# Altium schematic sheet СФ МЭИ ЛБ ПЭ2-18 11.03.04 03 Э3.SchDoc
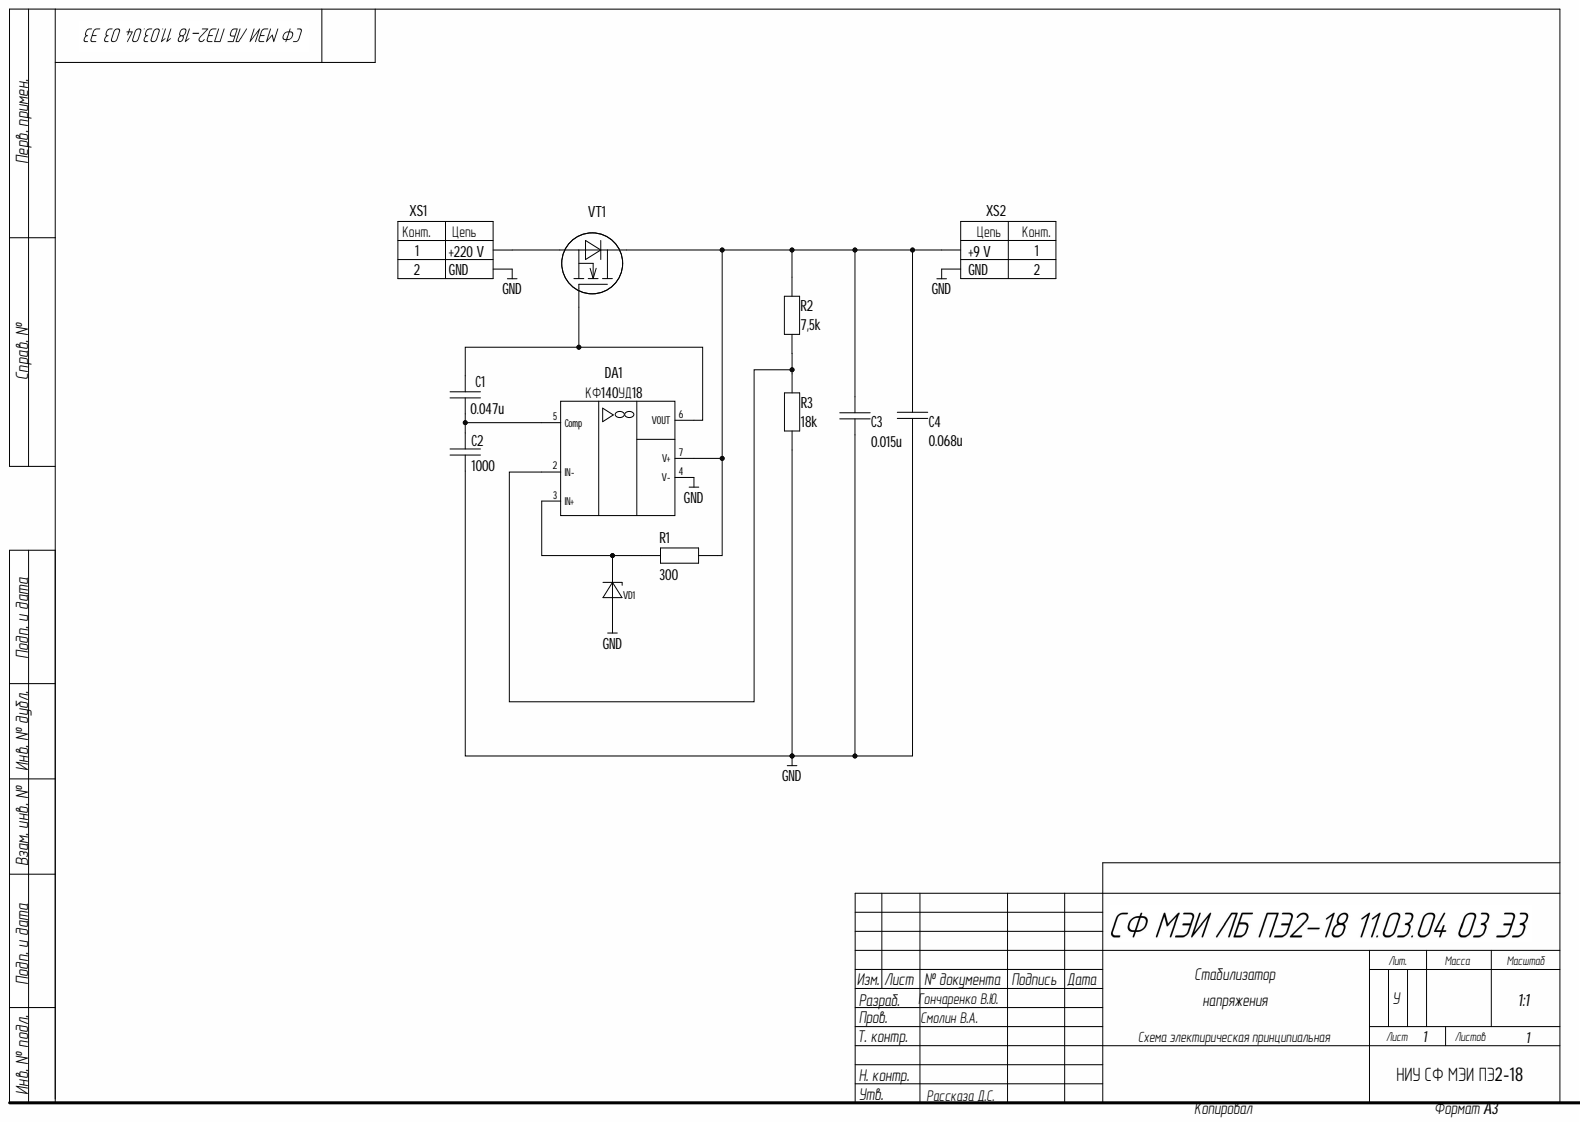
[diagram p0: СФ МЭИ ЛБ ПЭ2-18 11.03.04 03 Э3.SchDoc]

## Parts
C1  0.047u  [0805]
C2  1000  [0805]
C3  0.015u  [0805]
C4  0.068u  [0805]
DA1  КФ140УД18  [SOIC-8]
R1  300  [0805]
R2  7,5k  [0805]
R3  18k  [0805]
VD1  MMSZ5235B  [SOD-123]  (value not drawn)
VT1  IRLML2402TRPBF  [SOT23]  (value not drawn)
XS1  DG126-5.0-0.2P  [DG126-5.0-02P]  (value not drawn)
XS2  DG126-5.0-0.2P  [DG126-5.0-02P]  (value not drawn)

## Nets
GND: C2.1 C3.2 C4.1 DA1.4(V-) R3.1 VD1.1 XS1.2 XS2.2
NetC1_1: C1.1 C2.2 DA1.5(Comp)
NetC1_2: C1.2 DA1.6(VOUT) VT1.1
NetC3_1: C3.1 C4.2 DA1.7(V+) R1.2 R2.2 VT1.3 XS2.1
NetDA1_2: DA1.2 R2.1 R3.2
NetDA1_3: DA1.3 R1.1 VD1.2
NetVT1_2: VT1.2 XS1.1

## Library data of the parts
C1 = К53-68"R"-25В-0.047 мкФ ±10% 0805_3: К53-68"R"-25В-0.047 мкФ ±10%; Component Kind: Standard; Library Name: УГО.SchLib
C2 = К53-68"R"-25В-1000 пФ ±10% 0805_2: К53-68"R"-25В-1000 пФ ±10%; Component Kind: Standard; Library Name: УГО.SchLib
C3 = К53-68"R"-25В-0.015 мкФ ±10% 0805_1: К53-68"R"-25В-0.015 мкФ ±10%; Component Kind: Standard; Library Name: УГО.SchLib
C4 = К53-68"R"-25В-0.068 мкФ ±10% 0805: К53-68"R"-25В-0.068 мкФ ±10%; Component Kind: Standard; Library Name: УГО.SchLib
R1 = 0.125Вт 0805 300 Ом, 5%, Чип резистор (SMD): 0805-0.125 Вт -300 Ом±5%; Component Kind: Standard; Library Name: УГО.SchLib
R2 = 0.125Вт 0805 7,5 кОм, 5%, Чип резистор (SMD): 0805-0.125 Вт -7,5 кОм±5%; Component Kind: Standard; Library Name: УГО.SchLib
R3 = 0.125Вт 0805 18 кОм, 5%, Чип резистор (SMD): 0805-0.125 Вт -18 кОм±5%; Component Kind: Standard; Library Name: УГО.SchLib
VT1 = IRLML2402TRPBF: SI2304DDS-T1-GE3; Component Kind: Standard; Library Name: УГО.SchLib
DA1 = КФ140УД18: Component Kind: Standard; Description: КФ140УД18; Library Name: УГО.SchLib
VD1 = MMSZ5235B: Component Kind: Standard; Description: MMSZ5235B; Library Name: УГО.SchLib
XS1 XS2 = DG126-5.0-0.2P: Component Kind: Standard; Description: DG126-5.0-0.2P; Library Name: УГО.SchLib
